# Altium schematic sheet 4_FPGA.SchDoc(4_FPGA)
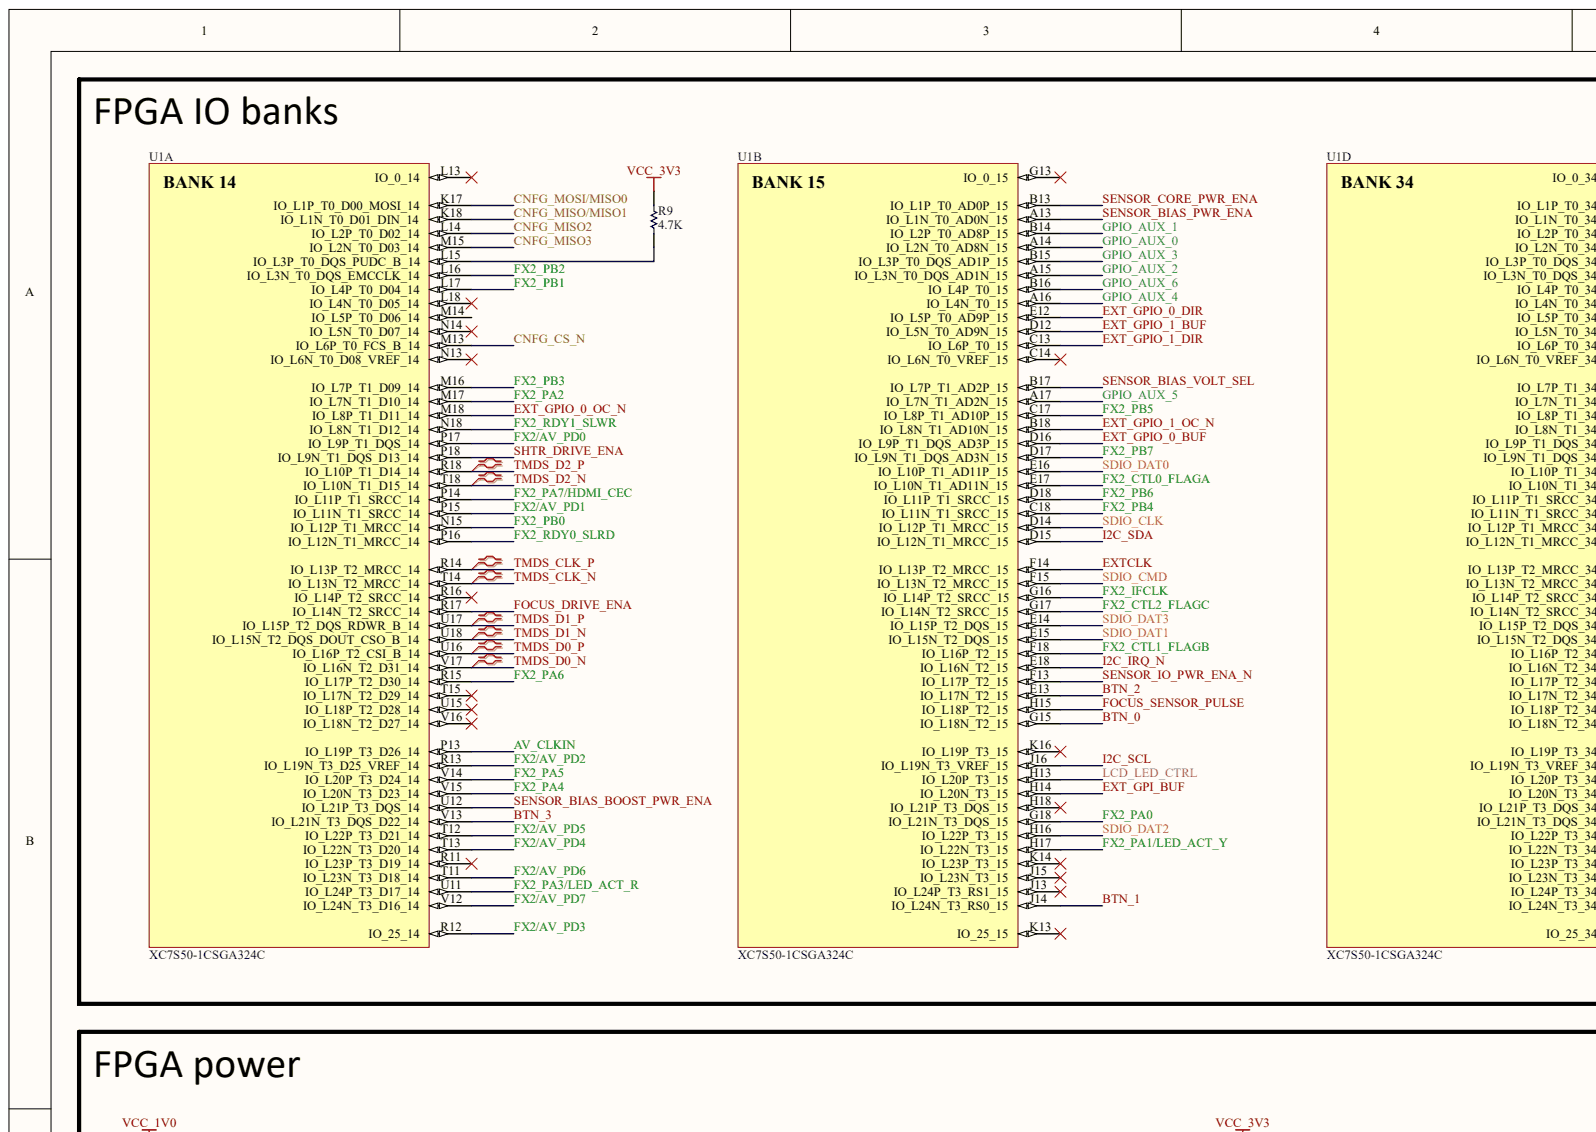
[diagram p0: 4_FPGA.SchDoc, top left]
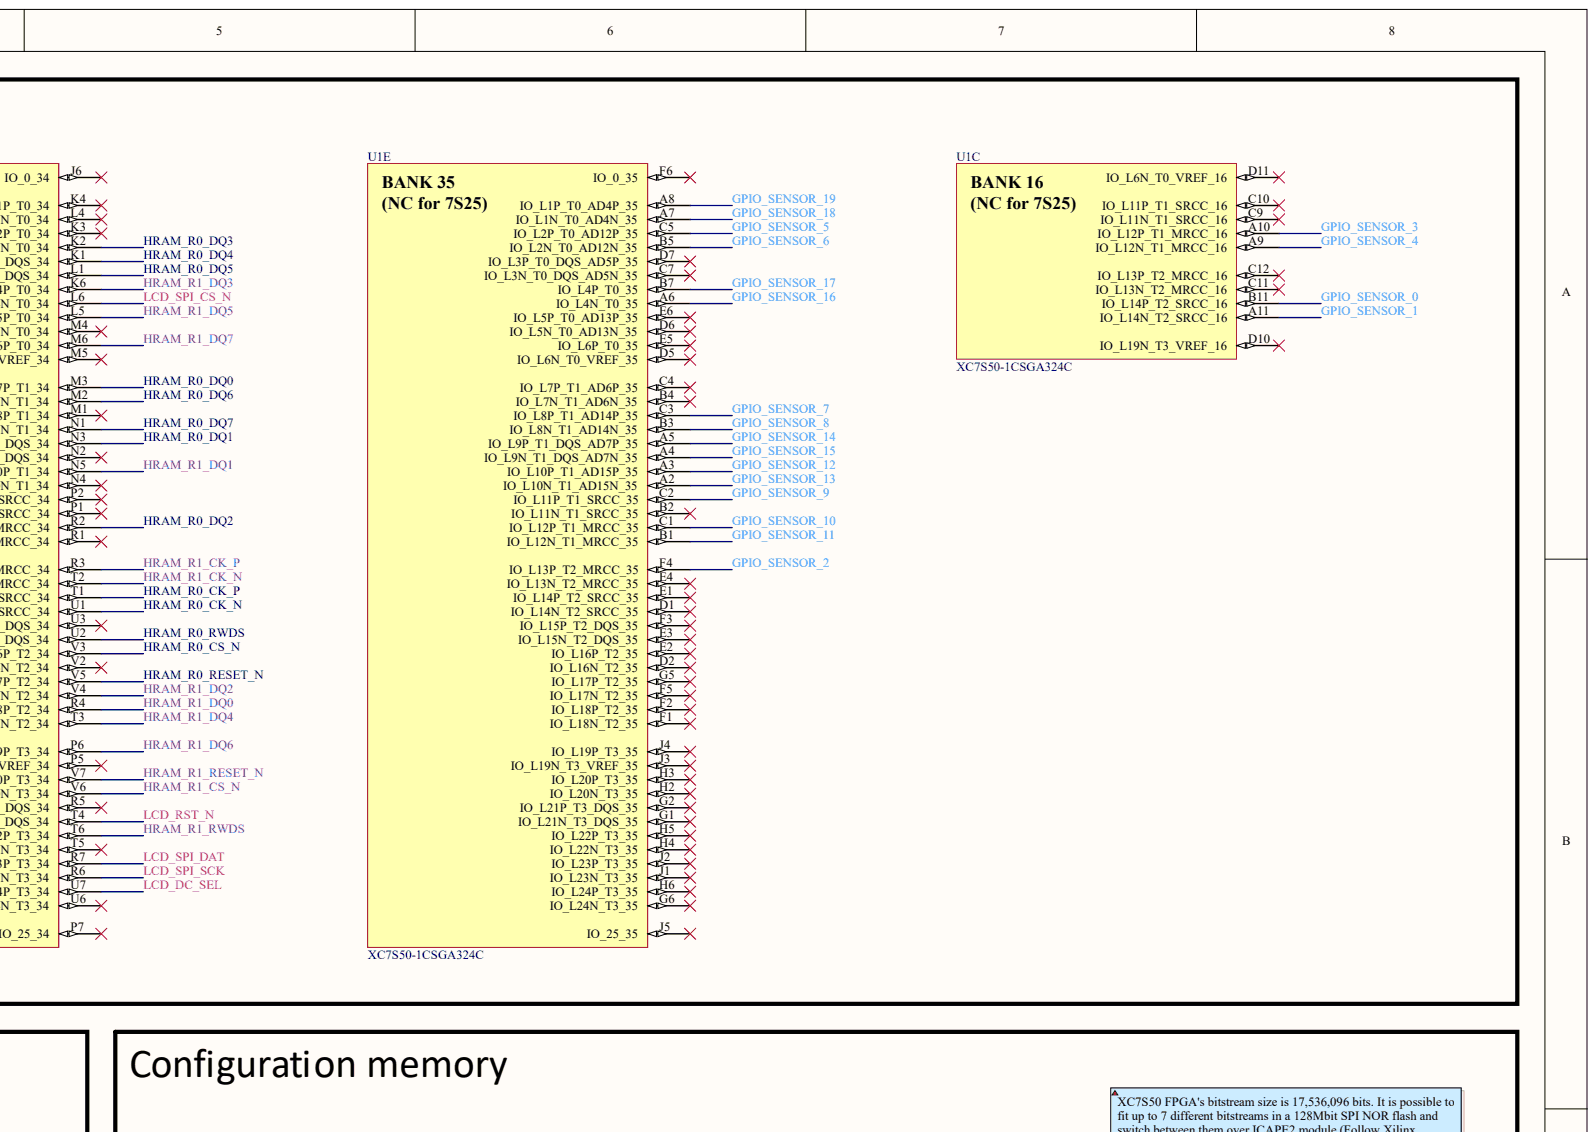
[diagram p1: 4_FPGA.SchDoc, top right]
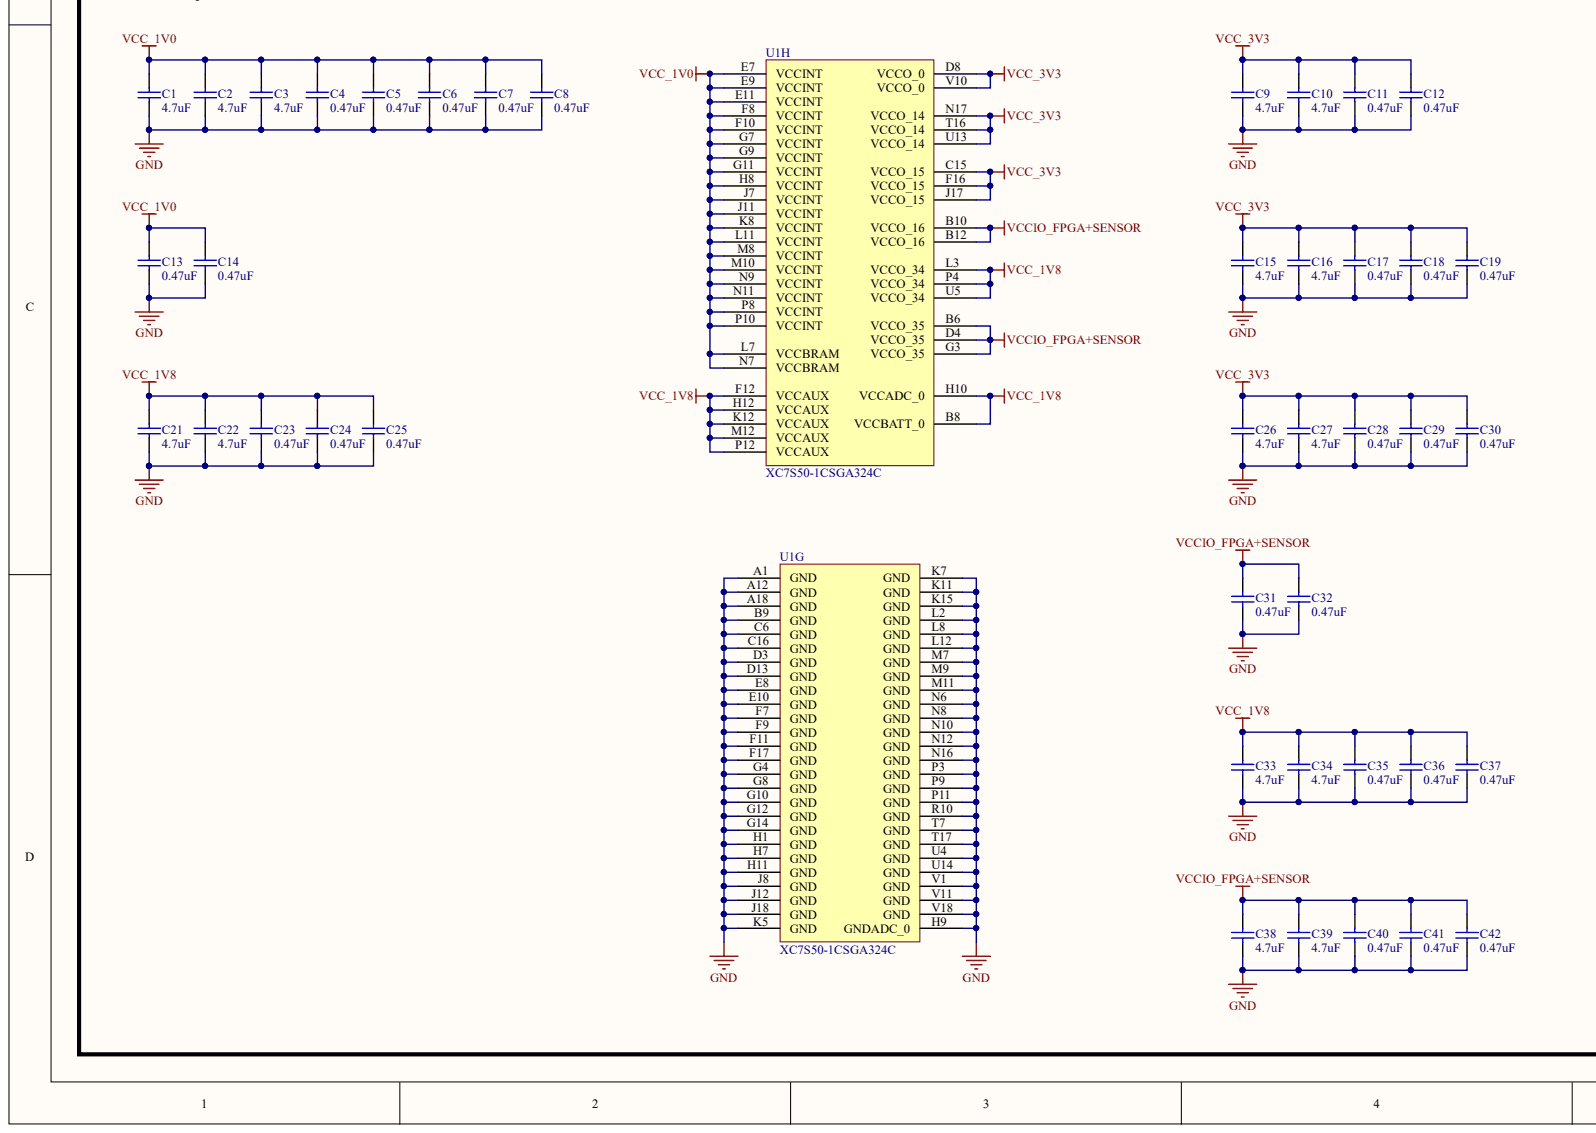
[diagram p2: 4_FPGA.SchDoc, bottom left]
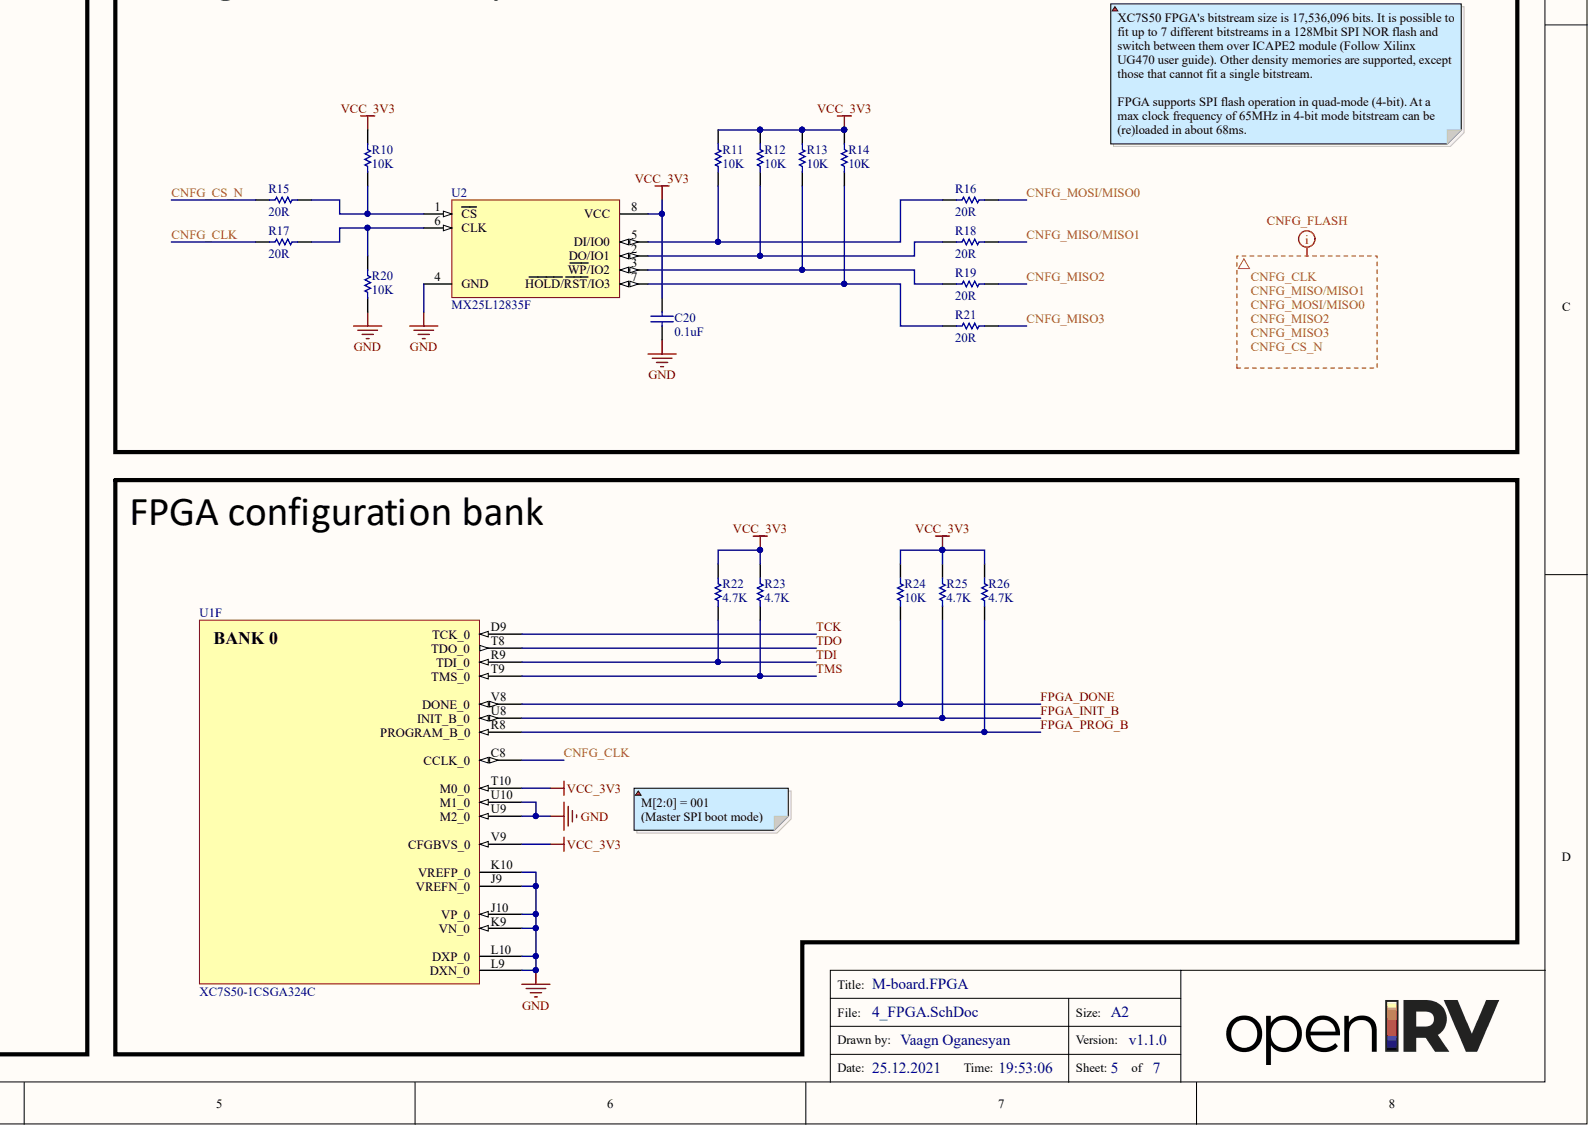
[diagram p3: 4_FPGA.SchDoc, bottom right]

## Parts
C1  4.7uF  [C0402[1005]]
C2  4.7uF  [C0402[1005]]
C3  4.7uF  [C0402[1005]]
C4  0.47uF  [C0402[1005]]
C5  0.47uF  [C0402[1005]]
C6  0.47uF  [C0402[1005]]
C7  0.47uF  [C0402[1005]]
C8  0.47uF  [C0402[1005]]
C9  4.7uF  [C0402[1005]]
C10  4.7uF  [C0402[1005]]
C11  0.47uF  [C0402[1005]]
C12  0.47uF  [C0402[1005]]
C13  0.47uF  [C0402[1005]]
C14  0.47uF  [C0402[1005]]
C15  4.7uF  [C0402[1005]]
C16  4.7uF  [C0402[1005]]
C17  0.47uF  [C0402[1005]]
C18  0.47uF  [C0402[1005]]
C19  0.47uF  [C0402[1005]]
C20  0.1uF  [C0402[1005]]
C21  4.7uF  [C0402[1005]]
C22  4.7uF  [C0402[1005]]
C23  0.47uF  [C0402[1005]]
C24  0.47uF  [C0402[1005]]
C25  0.47uF  [C0402[1005]]
C26  4.7uF  [C0402[1005]]
C27  4.7uF  [C0402[1005]]
C28  0.47uF  [C0402[1005]]
C29  0.47uF  [C0402[1005]]
C30  0.47uF  [C0402[1005]]
C31  0.47uF  [C0402[1005]]
C32  0.47uF  [C0402[1005]]
C33  4.7uF  [C0402[1005]]
C34  4.7uF  [C0402[1005]]
C35  0.47uF  [C0402[1005]]
C36  0.47uF  [C0402[1005]]
C37  0.47uF  [C0402[1005]]
C38  4.7uF  [C0402[1005]]
C39  4.7uF  [C0402[1005]]
C40  0.47uF  [C0402[1005]]
C41  0.47uF  [C0402[1005]]
C42  0.47uF  [C0402[1005]]
R9  4.7K  [R0402[1005]]
R10  10K  [R0402[1005]]
R11  10K  [R0402[1005]]
R12  10K  [R0402[1005]]
R13  10K  [R0402[1005]]
R14  10K  [R0402[1005]]
R15  20R  [R0402[1005]]
R16  20R  [R0402[1005]]
R17  20R  [R0402[1005]]
R18  20R  [R0402[1005]]
R19  20R  [R0402[1005]]
R20  10K  [R0402[1005]]
R21  20R  [R0402[1005]]
R22  4.7K  [R0402[1005]]
R23  4.7K  [R0402[1005]]
R24  10K  [R0402[1005]]
R25  4.7K  [R0402[1005]]
R26  4.7K  [R0402[1005]]
U1  XC7S50-1CSGA324C  [XC7S50-1CSGA324C]  (parts A,B,C,D,E,F,G,H)
U2  MX25L12835F  [MX25L12835F]

## Nets
GND: C1.2 C2.2 C3.2 C4.2 C5.2 C6.2 C7.2 C8.2 C9.2 C10.2 C11.2 C12.2 C13.2 C14.2 C15.2 C16.2 C17.2 C18.2 C19.2 C20.2 C21.2 C22.2 C23.2 C24.2 C25.2 C26.2 C27.2 C28.2 C29.2 C30.2 C31.2 C32.2 C33.2 C34.2 C35.2 C36.2 C37.2 C38.2 C39.2 C40.2 C41.2 C42.2 R20.1 U1.A1(GND) U1.A12(GND) U1.A18(GND) U1.B9(GND) U1.C6(GND) U1.C16(GND) U1.D3(GND) U1.D13(GND) U1.E8(GND) U1.E10(GND) U1.F7(GND) U1.F9(GND) U1.F11(GND) U1.F17(GND) U1.G4(GND) U1.G8(GND) U1.G10(GND) U1.G12(GND) U1.G14(GND) U1.H1(GND) U1.H7(GND) U1.H9(GNDADC_0) U1.H11(GND) U1.J8(GND) U1.J9(VREFN_0) U1.J10(VP_0) U1.J12(GND) U1.J18(GND) U1.K5(GND) U1.K7(GND) U1.K9(VN_0) U1.K10(VREFP_0) U1.K11(GND) U1.K15(GND) U1.L2(GND) U1.L8(GND) U1.L9(DXN_0) U1.L10(DXP_0) U1.L12(GND) U1.M7(GND) U1.M9(GND) U1.M11(GND) U1.N6(GND) U1.N8(GND) U1.N10(GND) U1.N12(GND) U1.N16(GND) U1.P3(GND) U1.P9(GND) U1.P11(GND) U1.R10(GND) U1.T7(GND) U1.T17(GND) U1.U4(GND) U1.U9(M2_0) U1.U10(M1_0) U1.U14(GND) U1.V1(GND) U1.V11(GND) U1.V18(GND) U2.4(GND)
VCC_1V0: C1.1 C2.1 C3.1 C4.1 C5.1 C6.1 C7.1 C8.1 C13.1 C14.1 U1.E7(VCCINT) U1.E9(VCCINT) U1.E11(VCCINT) U1.F8(VCCINT) U1.F10(VCCINT) U1.G7(VCCINT) U1.G9(VCCINT) U1.G11(VCCINT) U1.H8(VCCINT) U1.J7(VCCINT) U1.J11(VCCINT) U1.K8(VCCINT) U1.L7(VCCBRAM) U1.L11(VCCINT) U1.M8(VCCINT) U1.M10(VCCINT) U1.N7(VCCBRAM) U1.N9(VCCINT) U1.N11(VCCINT) U1.P8(VCCINT) U1.P10(VCCINT)
VCC_1V8: C21.1 C22.1 C23.1 C24.1 C25.1 C33.1 C34.1 C35.1 C36.1 C37.1 U1.B8(VCCBATT_0) U1.F12(VCCAUX) U1.H10(VCCADC_0) U1.H12(VCCAUX) U1.K12(VCCAUX) U1.L3(VCCO_34) U1.M12(VCCAUX) U1.P4(VCCO_34) U1.P12(VCCAUX) U1.U5(VCCO_34)
VCC_3V3: C9.1 C10.1 C11.1 C12.1 C15.1 C16.1 C17.1 C18.1 C19.1 C20.1 C26.1 C27.1 C28.1 C29.1 C30.1 R9.1 R10.2 R11.2 R12.2 R13.2 R14.2 R22.2 R23.2 R24.2 R25.2 R26.2 U1.C15(VCCO_15) U1.D8(VCCO_0) U1.F16(VCCO_15) U1.J17(VCCO_15) U1.N17(VCCO_14) U1.T10(M0_0) U1.T16(VCCO_14) U1.U13(VCCO_14) U1.V9(CFGBVS_0) U1.V10(VCCO_0) U2.8(VCC)
VCCIO_FPGA+SENSOR: C31.1 C32.1 C38.1 C39.1 C40.1 C41.1 C42.1 U1.B6(VCCO_35) U1.B10(VCCO_16) U1.B12(VCCO_16) U1.D4(VCCO_35) U1.G3(VCCO_35)
AV_CLKIN: U1.P13(IO_L19P_T3_D26_14)
BTN_0: U1.G15(IO_L18N_T2_15)
BTN_1: U1.J14(IO_L24N_T3_RS0_15)
BTN_2: U1.E13(IO_L17N_T2_15)
BTN_3: U1.V13(IO_L21N_T3_DQS_D22_14)
CNFG_CLK: R17.2 U1.C8(CCLK_0)
CNFG_CS_N: R15.2 U1.M13(IO_L6P_T0_FCS_B_14)
CNFG_MISO2: R19.1 U1.L14(IO_L2P_T0_D02_14)
CNFG_MISO3: R21.1 U1.M15(IO_L2N_T0_D03_14)
CNFG_MISO/MISO1: R18.1 U1.K18(IO_L1N_T0_D01_DIN_14)
CNFG_MOSI/MISO0: R16.1 U1.K17(IO_L1P_T0_D00_MOSI_14)
EXT_GPI_BUF: U1.H14(IO_L20N_T3_15)
EXT_GPIO_0_BUF: U1.D16(IO_L9P_T1_DQS_AD3P_15)
EXT_GPIO_0_DIR: U1.E12(IO_L5P_T0_AD9P_15)
EXT_GPIO_0_OC_N: U1.M18(IO_L8P_T1_D11_14)
EXT_GPIO_1_BUF: U1.D12(IO_L5N_T0_AD9N_15)
EXT_GPIO_1_DIR: U1.C13(IO_L6P_T0_15)
EXT_GPIO_1_OC_N: U1.B18(IO_L8N_T1_AD10N_15)
EXTCLK: U1.F14(IO_L13P_T2_MRCC_15)
FOCUS_DRIVE_ENA: U1.R17(IO_L14N_T2_SRCC_14)
FOCUS_SENSOR_PULSE: U1.H15(IO_L18P_T2_15)
FPGA_DONE: R24.1 U1.V8(DONE_0)
FPGA_INIT_B: R25.1 U1.U8(INIT_B_0)
FPGA_PROG_B: R26.1 U1.R8(PROGRAM_B_0)
FX2/AV_PD0: U1.P17(IO_L9P_T1_DQS_14)
FX2/AV_PD1: U1.P15(IO_L11N_T1_SRCC_14)
FX2/AV_PD2: U1.R13(IO_L19N_T3_D25_VREF_14)
FX2/AV_PD3: U1.R12(IO_25_14)
FX2/AV_PD4: U1.T13(IO_L22N_T3_D20_14)
FX2/AV_PD5: U1.T12(IO_L22P_T3_D21_14)
FX2/AV_PD6: U1.T11(IO_L23N_T3_D18_14)
FX2/AV_PD7: U1.V12(IO_L24N_T3_D16_14)
FX2_CTL0_FLAGA: U1.E17(IO_L10N_T1_AD11N_15)
FX2_CTL1_FLAGB: U1.F18(IO_L16P_T2_15)
FX2_CTL2_FLAGC: U1.G17(IO_L14N_T2_SRCC_15)
FX2_IFCLK: U1.G16(IO_L14P_T2_SRCC_15)
FX2_PA0: U1.G18(IO_L21N_T3_DQS_15)
FX2_PA1/LED_ACT_Y: U1.H17(IO_L22N_T3_15)
FX2_PA2: U1.M17(IO_L7N_T1_D10_14)
FX2_PA3/LED_ACT_R: U1.U11(IO_L24P_T3_D17_14)
FX2_PA4: U1.V15(IO_L20N_T3_D23_14)
FX2_PA5: U1.V14(IO_L20P_T3_D24_14)
FX2_PA6: U1.R15(IO_L17P_T2_D30_14)
FX2_PA7/HDMI_CEC: U1.P14(IO_L11P_T1_SRCC_14)
FX2_PB0: U1.N15(IO_L12P_T1_MRCC_14)
FX2_PB1: U1.L17(IO_L4P_T0_D04_14)
FX2_PB2: U1.L16(IO_L3N_T0_DQS_EMCCLK_14)
FX2_PB3: U1.M16(IO_L7P_T1_D09_14)
FX2_PB4: U1.C18(IO_L11N_T1_SRCC_15)
FX2_PB5: U1.C17(IO_L8P_T1_AD10P_15)
FX2_PB6: U1.D18(IO_L11P_T1_SRCC_15)
FX2_PB7: U1.D17(IO_L9N_T1_DQS_AD3N_15)
FX2_RDY0_SLRD: U1.P16(IO_L12N_T1_MRCC_14)
FX2_RDY1_SLWR: U1.N18(IO_L8N_T1_D12_14)
GPIO_AUX_0: U1.A14(IO_L2N_T0_AD8N_15)
GPIO_AUX_1: U1.B14(IO_L2P_T0_AD8P_15)
GPIO_AUX_2: U1.A15(IO_L3N_T0_DQS_AD1N_15)
GPIO_AUX_3: U1.B15(IO_L3P_T0_DQS_AD1P_15)
GPIO_AUX_4: U1.A16(IO_L4N_T0_15)
GPIO_AUX_5: U1.A17(IO_L7N_T1_AD2N_15)
GPIO_AUX_6: U1.B16(IO_L4P_T0_15)
GPIO_SENSOR_0: U1.B11(IO_L14P_T2_SRCC_16)
GPIO_SENSOR_1: U1.A11(IO_L14N_T2_SRCC_16)
GPIO_SENSOR_2: U1.F4(IO_L13P_T2_MRCC_35)
GPIO_SENSOR_3: U1.A10(IO_L12P_T1_MRCC_16)
GPIO_SENSOR_4: U1.A9(IO_L12N_T1_MRCC_16)
GPIO_SENSOR_5: U1.C5(IO_L2P_T0_AD12P_35)
GPIO_SENSOR_6: U1.B5(IO_L2N_T0_AD12N_35)
GPIO_SENSOR_7: U1.C3(IO_L8P_T1_AD14P_35)
GPIO_SENSOR_8: U1.B3(IO_L8N_T1_AD14N_35)
GPIO_SENSOR_9: U1.C2(IO_L11P_T1_SRCC_35)
GPIO_SENSOR_10: U1.C1(IO_L12P_T1_MRCC_35)
GPIO_SENSOR_11: U1.B1(IO_L12N_T1_MRCC_35)
GPIO_SENSOR_12: U1.A3(IO_L10P_T1_AD15P_35)
GPIO_SENSOR_13: U1.A2(IO_L10N_T1_AD15N_35)
GPIO_SENSOR_14: U1.A5(IO_L9P_T1_DQS_AD7P_35)
GPIO_SENSOR_15: U1.A4(IO_L9N_T1_DQS_AD7N_35)
GPIO_SENSOR_16: U1.A6(IO_L4N_T0_35)
GPIO_SENSOR_17: U1.B7(IO_L4P_T0_35)
GPIO_SENSOR_18: U1.A7(IO_L1N_T0_AD4N_35)
GPIO_SENSOR_19: U1.A8(IO_L1P_T0_AD4P_35)
HRAM_R0_CK_N: U1.U1(IO_L14N_T2_SRCC_34)
HRAM_R0_CK_P: U1.T1(IO_L14P_T2_SRCC_34)
HRAM_R0_CS_N: U1.V3(IO_L16P_T2_34)
HRAM_R0_DQ0: U1.M3(IO_L7P_T1_34)
HRAM_R0_DQ1: U1.N3(IO_L9P_T1_DQS_34)
HRAM_R0_DQ2: U1.R2(IO_L12P_T1_MRCC_34)
HRAM_R0_DQ3: U1.K2(IO_L2N_T0_34)
HRAM_R0_DQ4: U1.K1(IO_L3P_T0_DQS_34)
HRAM_R0_DQ5: U1.L1(IO_L3N_T0_DQS_34)
HRAM_R0_DQ6: U1.M2(IO_L7N_T1_34)
HRAM_R0_DQ7: U1.N1(IO_L8N_T1_34)
HRAM_R0_RESET_N: U1.V5(IO_L17P_T2_34)
HRAM_R0_RWDS: U1.U2(IO_L15N_T2_DQS_34)
HRAM_R1_CK_N: U1.T2(IO_L13N_T2_MRCC_34)
HRAM_R1_CK_P: U1.R3(IO_L13P_T2_MRCC_34)
HRAM_R1_CS_N: U1.V6(IO_L20N_T3_34)
HRAM_R1_DQ0: U1.R4(IO_L18P_T2_34)
HRAM_R1_DQ1: U1.N5(IO_L10P_T1_34)
HRAM_R1_DQ2: U1.V4(IO_L17N_T2_34)
HRAM_R1_DQ3: U1.K6(IO_L4P_T0_34)
HRAM_R1_DQ4: U1.T3(IO_L18N_T2_34)
HRAM_R1_DQ5: U1.L5(IO_L5P_T0_34)
HRAM_R1_DQ6: U1.P6(IO_L19P_T3_34)
HRAM_R1_DQ7: U1.M6(IO_L6P_T0_34)
HRAM_R1_RESET_N: U1.V7(IO_L20P_T3_34)
HRAM_R1_RWDS: U1.T6(IO_L22P_T3_34)
I2C_IRQ_N: U1.E18(IO_L16N_T2_15)
I2C_SCL: U1.J16(IO_L19N_T3_VREF_15)
I2C_SDA: U1.D15(IO_L12N_T1_MRCC_15)
LCD_DC_SEL: U1.U7(IO_L24P_T3_34)
LCD_LED_CTRL: U1.H13(IO_L20P_T3_15)
LCD_RST_N: U1.T4(IO_L21N_T3_DQS_34)
LCD_SPI_CS_N: U1.L6(IO_L4N_T0_34)
LCD_SPI_DAT: U1.R7(IO_L23P_T3_34)
LCD_SPI_SCK: U1.R6(IO_L23N_T3_34)
SDIO_CLK: U1.D14(IO_L12P_T1_MRCC_15)
SDIO_CMD: U1.F15(IO_L13N_T2_MRCC_15)
SDIO_DAT0: U1.E16(IO_L10P_T1_AD11P_15)
SDIO_DAT1: U1.E15(IO_L15N_T2_DQS_15)
SDIO_DAT2: U1.H16(IO_L22P_T3_15)
SDIO_DAT3: U1.E14(IO_L15P_T2_DQS_15)
SENSOR_BIAS_BOOST_PWR_ENA: U1.U12(IO_L21P_T3_DQS_14)
SENSOR_BIAS_PWR_ENA: U1.A13(IO_L1N_T0_AD0N_15)
SENSOR_BIAS_VOLT_SEL: U1.B17(IO_L7P_T1_AD2P_15)
SENSOR_CORE_PWR_ENA: U1.B13(IO_L1P_T0_AD0P_15)
SENSOR_IO_PWR_ENA_N: U1.F13(IO_L17P_T2_15)
SHTR_DRIVE_ENA: U1.P18(IO_L9N_T1_DQS_D13_14)
TCK: U1.D9(TCK_0)
TDI: R22.1 U1.R9(TDI_0)
TDO: U1.T8(TDO_0)
TMDS_CLK_N: U1.T14(IO_L13N_T2_MRCC_14)
TMDS_CLK_P: U1.R14(IO_L13P_T2_MRCC_14)
TMDS_D0_N: U1.V17(IO_L16N_T2_D31_14)
TMDS_D0_P: U1.U16(IO_L16P_T2_CSI_B_14)
TMDS_D1_N: U1.U18(IO_L15N_T2_DQS_DOUT_CSO_B_14)
TMDS_D1_P: U1.U17(IO_L15P_T2_DQS_RDWR_B_14)
TMDS_D2_N: U1.T18(IO_L10N_T1_D15_14)
TMDS_D2_P: U1.R18(IO_L10P_T1_D14_14)
TMS: R23.1 U1.T9(TMS_0)
NetR9_2: R9.2 U1.L15(IO_L3P_T0_DQS_PUDC_B_14)
NetR10_1: R10.1 R15.1 U2.1(CS)
NetR11_1: R11.1 R16.2 U2.5(DI/IO0)
NetR12_1: R12.1 R18.2 U2.2(DO/IO1)
NetR13_1: R13.1 R19.2 U2.3(WP/IO2)
NetR14_1: R14.1 R21.2 U2.7(HOLD/RST/IO3)
NetR17_1: R17.1 R20.2 U2.6(CLK)
N00315:

Not connected: U1 B2(IO_L11N_T1_SRCC_35) B4(IO_L7N_T1_AD6N_35) C4(IO_L7P_T1_AD6P_35) C7(IO_L3N_T0_DQS_AD5N_35) C9(IO_L11N_T1_SRCC_16) C10(IO_L11P_T1_SRCC_16) C11(IO_L13N_T2_MRCC_16) C12(IO_L13P_T2_MRCC_16) C14(IO_L6N_T0_VREF_15) D1(IO_L14N_T2_SRCC_35) D2(IO_L16N_T2_35) D5(IO_L6N_T0_VREF_35) D6(IO_L5N_T0_AD13N_35) D7(IO_L3P_T0_DQS_AD5P_35) D10(IO_L19N_T3_VREF_16) D11(IO_L6N_T0_VREF_16) E1(IO_L14P_T2_SRCC_35) E2(IO_L16P_T2_35) E3(IO_L15N_T2_DQS_35) E4(IO_L13N_T2_MRCC_35) E5(IO_L6P_T0_35) E6(IO_L5P_T0_AD13P_35) F1(IO_L18N_T2_35) F2(IO_L18P_T2_35) F3(IO_L15P_T2_DQS_35) F5(IO_L17N_T2_35) F6(IO_0_35) G1(IO_L21N_T3_DQS_35) G2(IO_L21P_T3_DQS_35) G5(IO_L17P_T2_35) G6(IO_L24N_T3_35) G13(IO_0_15) H2(IO_L20N_T3_35) H3(IO_L20P_T3_35) H4(IO_L22N_T3_35) H5(IO_L22P_T3_35) H6(IO_L24P_T3_35) H18(IO_L21P_T3_DQS_15) J1(IO_L23N_T3_35) J2(IO_L23P_T3_35) J3(IO_L19N_T3_VREF_35) J4(IO_L19P_T3_35) J5(IO_25_35) J6(IO_0_34) J13(IO_L24P_T3_RS1_15) J15(IO_L23N_T3_15) K3(IO_L2P_T0_34) K4(IO_L1P_T0_34) K13(IO_25_15) K14(IO_L23P_T3_15) K16(IO_L19P_T3_15) L4(IO_L1N_T0_34) L13(IO_0_14) L18(IO_L4N_T0_D05_14) M1(IO_L8P_T1_34) M4(IO_L5N_T0_34) M5(IO_L6N_T0_VREF_34) M14(IO_L5P_T0_D06_14) N2(IO_L9N_T1_DQS_34) N4(IO_L10N_T1_34) N13(IO_L6N_T0_D08_VREF_14) N14(IO_L5N_T0_D07_14) P1(IO_L11N_T1_SRCC_34) P2(IO_L11P_T1_SRCC_34) P5(IO_L19N_T3_VREF_34) P7(IO_25_34) R1(IO_L12N_T1_MRCC_34) R5(IO_L21P_T3_DQS_34) R11(IO_L23P_T3_D19_14) R16(IO_L14P_T2_SRCC_14) T5(IO_L22N_T3_34) T15(IO_L17N_T2_D29_14) U3(IO_L15P_T2_DQS_34) U6(IO_L24N_T3_34) U15(IO_L18P_T2_D28_14) V2(IO_L16N_T2_34) V16(IO_L18N_T2_D27_14)

## Library data of the parts
C1-C19 C21-C42 = CAP: Dielectric: X5R; Tolerance: 10%; Voltage: 6.3V; Component Kind: Standard; Library Name: general.IntLib; Mounting: SMD
  C1-C3 C9 C10 C15 C16 C21 C22 C26 C27 C33 C34 C38 C39: Value: 4.7uF
  C4-C8 C11-C14 C17-C19 C23-C25 C28-C32 C35-C37 C40-C42: Value: 0.47uF
C20 = CAP: Dielectric: X7R; Tolerance: 10%; Voltage: 50V; Component Kind: Standard; Library Name: general.IntLib; Mounting: SMD; Value: 0.1uF
R9-R26 = RES: Power: 0.06W; Tolerance: 5%; Component Kind: Standard; Library Name: general.IntLib; Mounting: SMD
  R9 R22 R23 R25 R26: Value: 4.7K
  R10-R14 R20 R24: Value: 10K
  R15-R19 R21: Value: 20R
U1 = XC7S50-1CSGA324C: Spartan-7 FPGA, pin compatible with 7S25; Component Kind: Standard; Library Name: general.IntLib
U2 = MX25L12835F: Quad SPI NOR Flash 128Mbit; Component Kind: Standard; Library Name: general.IntLib
